# Altium schematic sheet Daughter Board CAN.SchDoc("Daughter Board CAN")
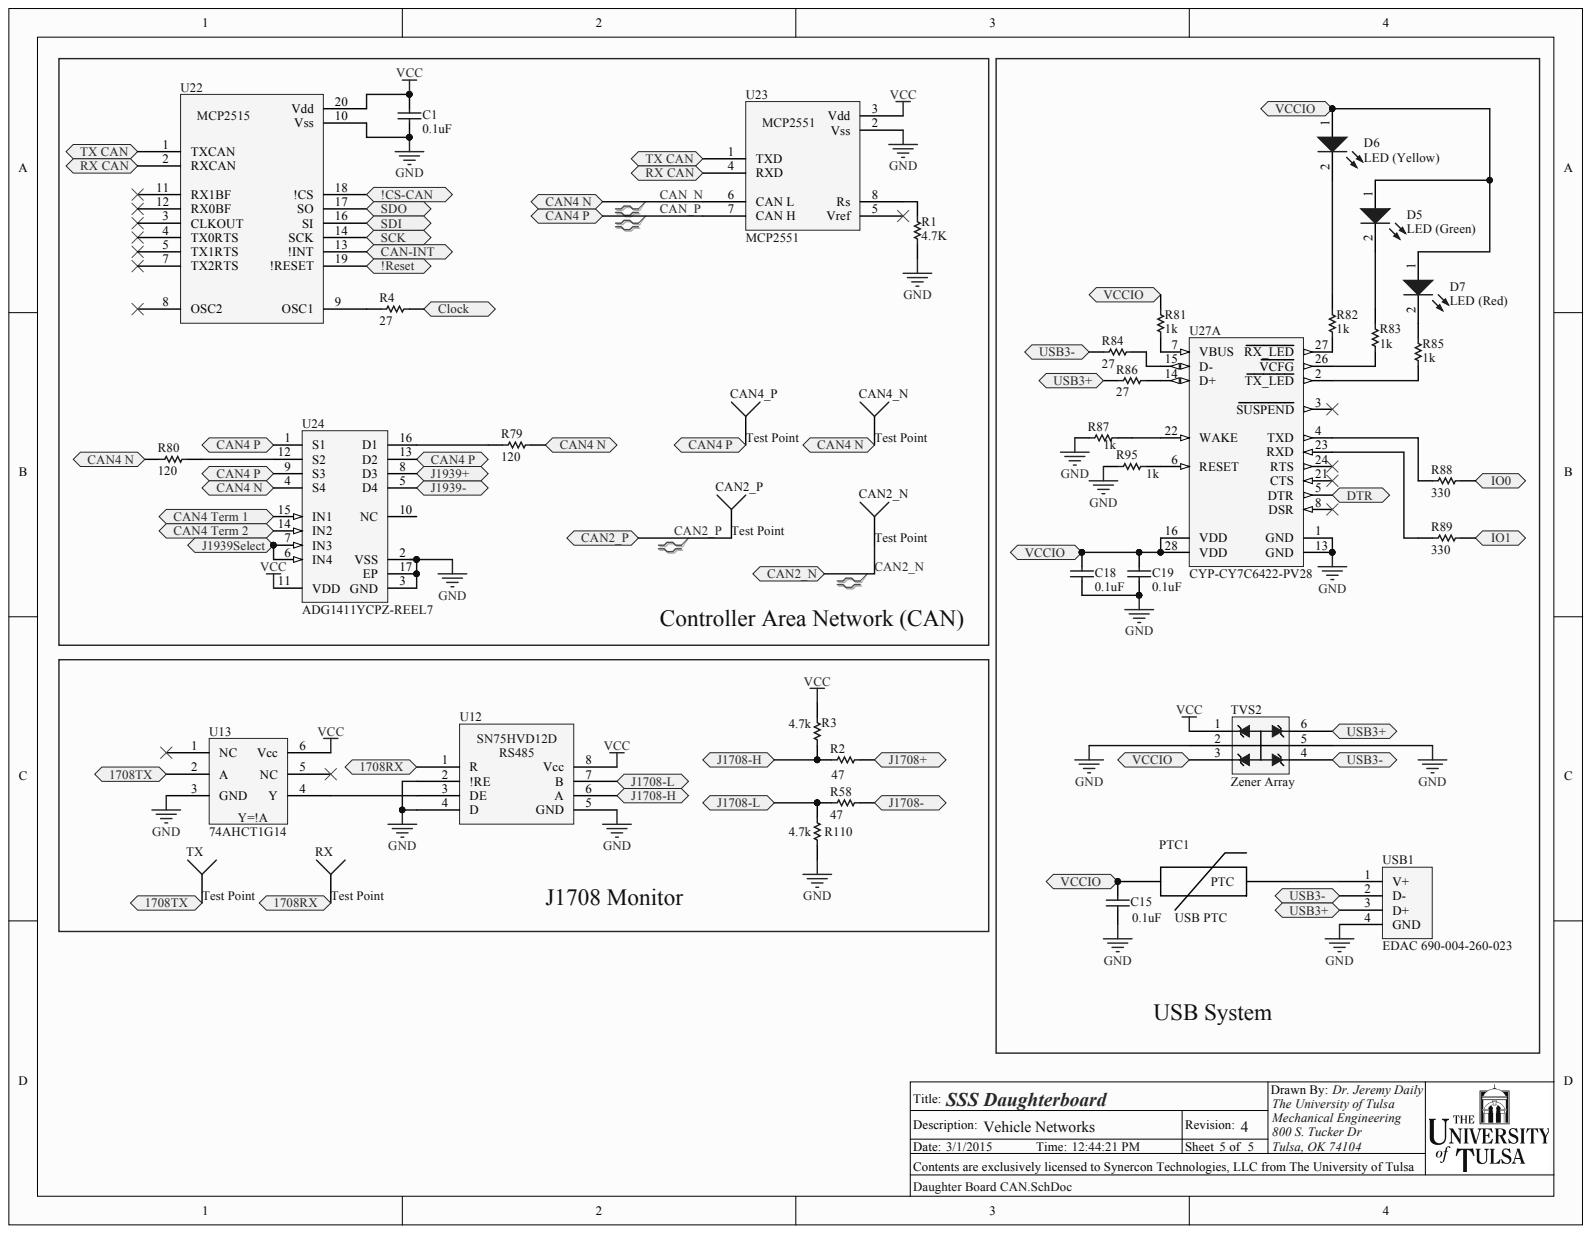
[diagram p0: Daughter Board CAN.SchDoc]

## Parts
C1  0.1uF  [0603]
C15  0.1uF  [0603]
C18  0.1uF  [0603]
C19  0.1uF  [0603]
CAN2_N  Test Point  [PIN1]
CAN2_P  Test Point  [PIN1]
CAN4_N  Test Point  [PIN1]
CAN4_P  Test Point  [PIN1]
D5  LED (Green)  [0805 Polarized]
D6  LED (Yellow)  [0805 Polarized]
D7  LED (Red)  [0805 Polarized]
PTC1  USB PTC  [1812]
R1  4.7K  [0805]
R2  47  [0805]
R3  4.7k  [0805]
R4  27  [0805]
R58  47  [0805]
R79  120  [0805]
R80  120  [0805]
R81  1k  [0805]
R82  1k  [0805]
R83  1k  [0805]
R84  27  [0805]
R85  1k  [0805]
R86  27  [0805]
R87  1k  [0805]
R88  330  [0805]
R89  330  [0805]
R95  1k  [0805]
R110  4.7k  [0805]
RX  Test Point  [PIN1]
TVS2  Zener Array  [SOT23-6]
TX  Test Point  [PIN1]
U12  SN75HVD12D (3.3v RS485)  [SOIC-8]  (value not drawn)
U13  74AHCT1G14  [SOT-353]
U22  MCP2515 (TSSOP)  [TI-TSSOP20]  (value not drawn)
U23  MCP2551  [SOIC-8]
U24  ADG1411YCPZ-REEL7  [ADI-CP-16-13_M]
U27  CYP-CY7C6422-PV28  [CYP-PVX28_M]  (part A)
USB1  EDAC 690-004-260-023  [USB B Vertical]

## Ports
1708RX, 1708TX, !CS-CAN, !RESET, CAN2_N, CAN2_P, CAN4 N, CAN4 P, CAN4 TERM 1, CAN4 TERM 2, CAN-INT, CLOCK, DTR, IO0, IO1, J1708+, J1708-, J1708-H, J1708-L, J1939+, J1939-, J1939SELECT, RX CAN, SCK, SDI, SDO, TX CAN, USB3+, USB3-, VCCIO

## Nets
GND: C1.1 C15.2 C18.2 C19.2 R1.2 R87.2 R95.2 R110.2 TVS2.2 TVS2.5 U12.2(!RE) U12.4(D) U12.5(GND) U13.3(GND) U22.10(Vss) U23.2(Vss) U24.2(VSS) U24.3(GND) U24.17(EP) U27.1(GND) U27.13(GND) USB1.4(GND)
VCC: C1.2 R3.1 TVS2.1 U12.8(Vcc) U13.6(Vcc) U22.20(Vdd) U23.3(Vdd) U24.11(VDD)
1708RX: RX.1 U12.1(R) ; port 1708RX
1708TX: TX.1 U13.2(A) ; port 1708TX
!CS-CAN: U22.18(!CS) ; port !CS-CAN
CAN2_N: CAN2_N.1 ; port CAN2_N
CAN2_P: CAN2_P.1 ; port CAN2_P
CAN-INT: U22.13(!INT) ; port CAN-INT
CAN_N: CAN4_N.1 R79.1 R80.2 U23.6(CAN_L) U24.4(S4) ; port CAN4 N
CAN_P: CAN4_P.1 U23.7(CAN_H) U24.1(S1) U24.9(S3) U24.13(D2) ; port CAN4 P
DTR: U27.5(DTR) ; port DTR
IO0: R88.1 ; port IO0
IO1: R89.1 ; port IO1
J1708-H: R2.1 R3.2 U12.6(A) ; port J1708-H
J1708-L: R58.1 R110.1 U12.7(B) ; port J1708-L
RX CAN: U22.2(RXCAN) U23.4(RXD) ; port RX CAN
SCK: U22.14(SCK) ; port SCK
SDI: U22.16(SI) ; port SDI
SDO: U22.17(SO) ; port SDO
TX CAN: U22.1(TXCAN) U23.1(TXD) ; port TX CAN
VCCIO: C15.1 C18.1 C19.1 D5.1 D6.1 D7.1 PTC1.1 R81.1 TVS2.3 U27.16(VDD) U27.28(VDD) ; port VCCIO
NetD5_2: D5.2 R83.1
NetD6_2: D6.2 R82.1
NetD7_2: D7.2 R85.1
NetPTC1_2: PTC1.2 USB1.1(V+)
NetR1_1: R1.1 U23.8(Rs)
NetR2_2: R2.2
NetR4_1: R4.1 U22.9(OSC1)
NetR4_2: R4.2 ; port CLOCK
NetR58_2: R58.2
NetR79_2: R79.2 U24.16(D1)
NetR80_1: R80.1 U24.12(S2)
NetR81_2: R81.2 U27.7(VBUS)
NetR82_2: R82.2 U27.27(RX_LED)
NetR83_2: R83.2 U27.26(VCFG)
NetR84_1: R84.1 U27.15(D-)
NetR84_2: R84.2 TVS2.4 USB1.2(D-)
NetR85_2: R85.2 U27.2(TX_LED)
NetR86_1: R86.1 U27.14(D+)
NetR86_2: R86.2 TVS2.6 USB1.3(D+)
NetR87_1: R87.1 U27.22(WAKE)
NetR88_2: R88.2 U27.4(TXD)
NetR89_2: R89.2 U27.23(RXD)
NetR95_1: R95.1 U27.6(RESET)
NetU12_3: U12.3(DE) U13.4(Y)
NetU22_19: U22.19(!RESET) ; port !RESET
NetU24_5: U24.5(D4)
NetU24_6: U24.6(IN4) U24.7(IN3) ; port J1939SELECT
NetU24_8: U24.8(D3)
NetU24_14: U24.14(IN2) ; port CAN4 TERM 2
NetU24_15: U24.15(IN1) ; port CAN4 TERM 1
N00006:

Not connected: U13 1(NC) 5(NC); U22 3(CLKOUT) 4(TX0RTS) 5(TX1RTS) 7(TX2RTS) 8(OSC2) 11(RX1BF) 12(RX0BF); U23 5(Vref); U24 10(NC); U27 3(SUSPEND) 8(DSR) 21(CTS) 24(RTS)

## Library data of the parts
C1 C15 C18 C19 = Cap: Component Kind: Standard; Signal Integrity: Cap; Simulation: CAP; Value: 0.1uF; Library Name: Daily_Integrated_Library.IntLib
CAN2_N CAN2_P CAN4_N CAN4_P RX TX = Socket: Component Kind: Standard; Library Name: Miscellaneous Connectors.IntLib; Signal Integrity: Connector; Value: Test Point
D5-D7 = SMT LED: Component Kind: Standard; Library Name: SSS Schematic Library.SchLib
PTC1 = PTC: PTC RESTTBLE 0.75A 33V CHIP 1812; Manufacturer: Bel Fuse Inc; PartNumber: 0ZCG0075BF2B; Component Kind: Standard; Library Name: SSS Schematic Library.SchLib; Supplier 1: Digi-Key; Supplier Part Number 1: 507-1765-1-ND
R1-R4 R58 R84 R86 R110 = Chip Resistor: Component Kind: Standard
  R1: Library Name: KongsLib.SchLib
  R2-R4 R58 R84 R86 R110: Library Name: KongsLib.IntLib
R79 R80 = Chip Resistor: Manufacturer: Rohm Semiconductor; Component Kind: Standard; Library Name: Daily_Integrated_Library.IntLib
R81-R83 R85 R87-R89 R95 = SMD Resistor: Component Kind: Standard; Library Name: Resistors.IntLib
TVS2 = TVS Diode Array: Component Kind: Standard; Library Name: Protection Devices.SchLib; Supplier 1: Digi-Key; Supplier Part Number 1: 732-4476-1-ND
U13 = 74AHCT1G14 Inverter: Component Kind: Standard; Library Name: SSS Schematic Library.SchLib
U24 = ADG1411YCPZ-REEL7: Manufacturer: Analog Devices; Component Kind: Standard; Library Name: Analog Devices Switches and Multiplexers Analog Switches.IntLib; PartNumber: ADG1411YCPZ-REEL7; Supplier 1: Digi-Key; Supplier Part Number 1: ADG1411YCPZ-REEL7TR-ND
U27 = CMP-0967-00141-1: Component Kind: Standard
USB1 = USB-Rugged: Component Kind: Standard; Library Name: SSS Schematic Library.SchLib
U12 = SN75HVD12D (3.3v RS485): Component Kind: Standard; Library Name: Communications.IntLib
U22 = MCP2515 (TSSOP): Component Kind: Standard; Library Name: Communications.SchLib
U23 = MCP2551: Component Kind: Standard; Library Name: KongsLib.IntLib
